# Altium schematic sheet sch6.SchDoc
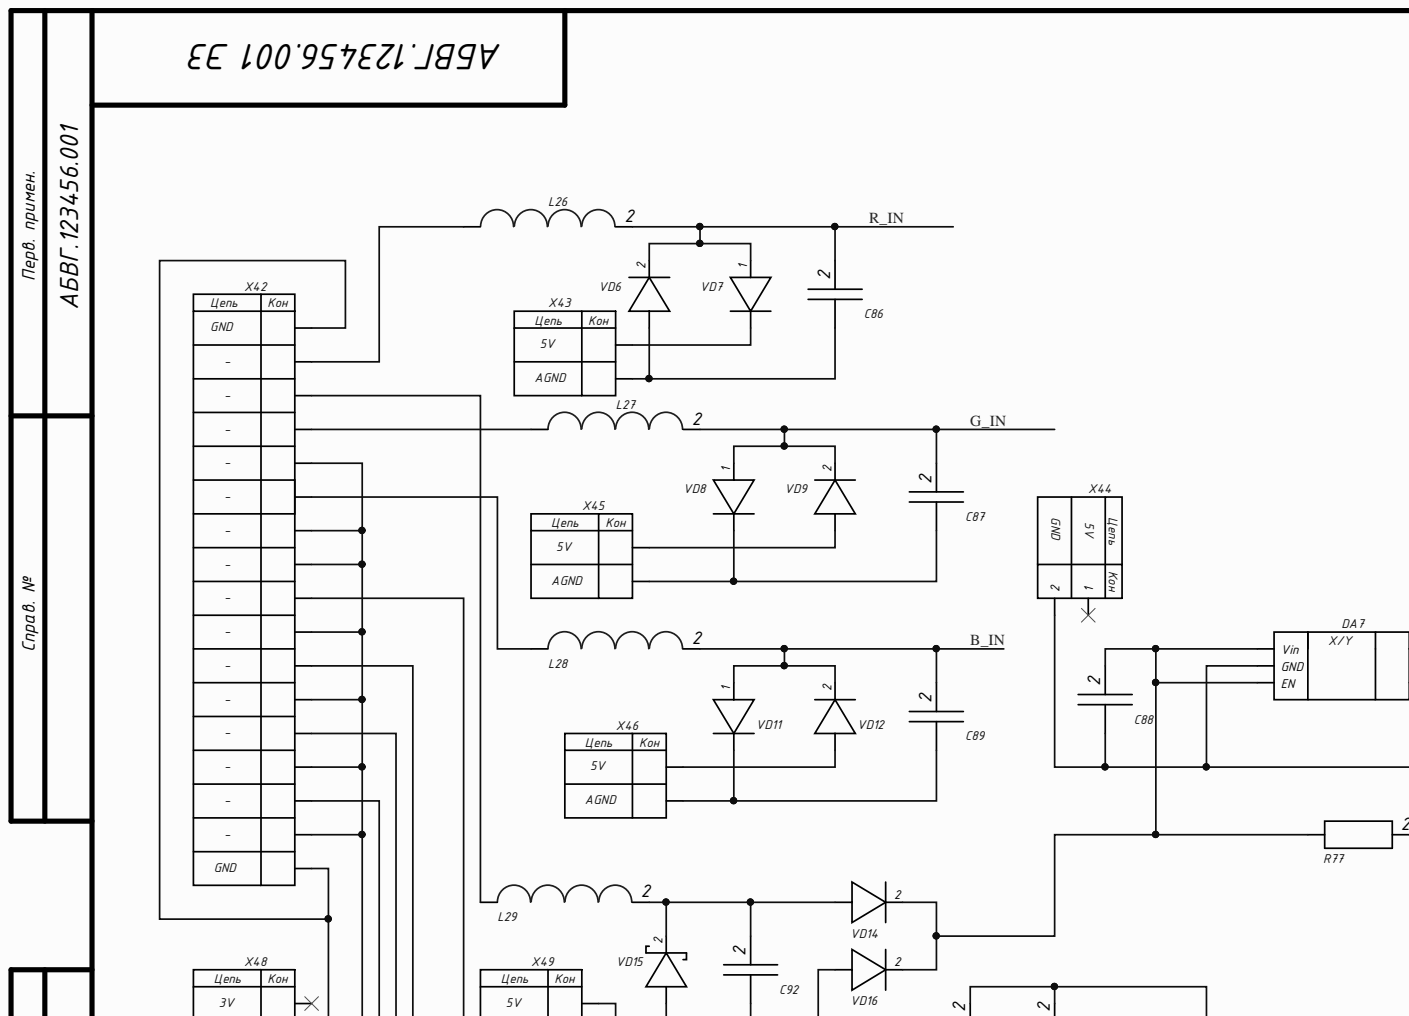
[diagram p0: sch6.SchDoc, top left]
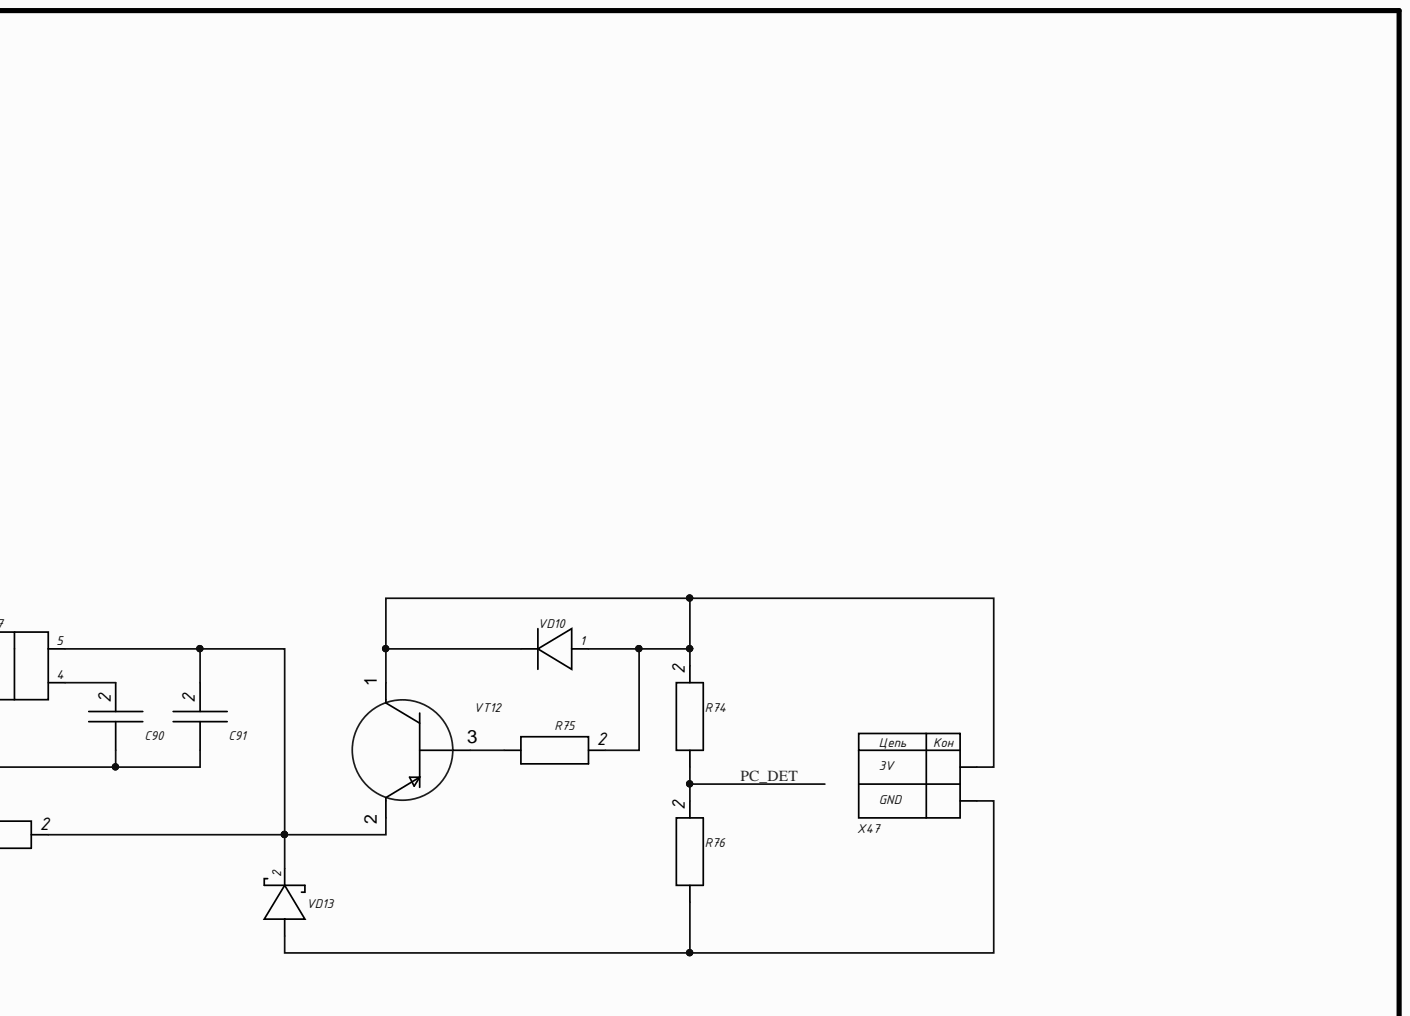
[diagram p1: sch6.SchDoc, top right]
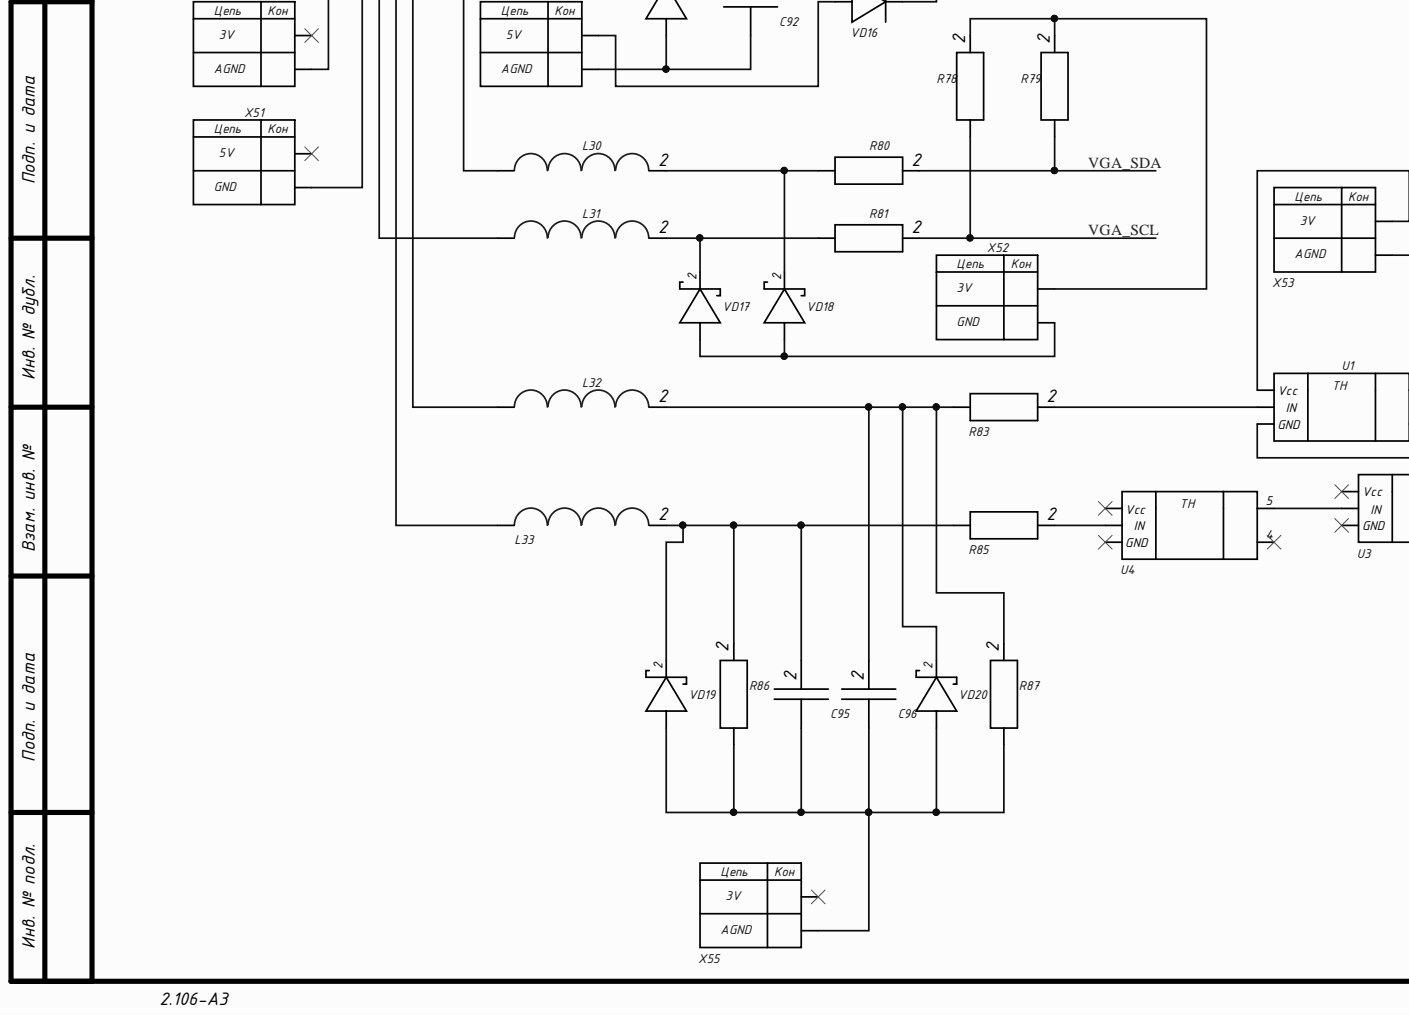
[diagram p2: sch6.SchDoc, bottom left]
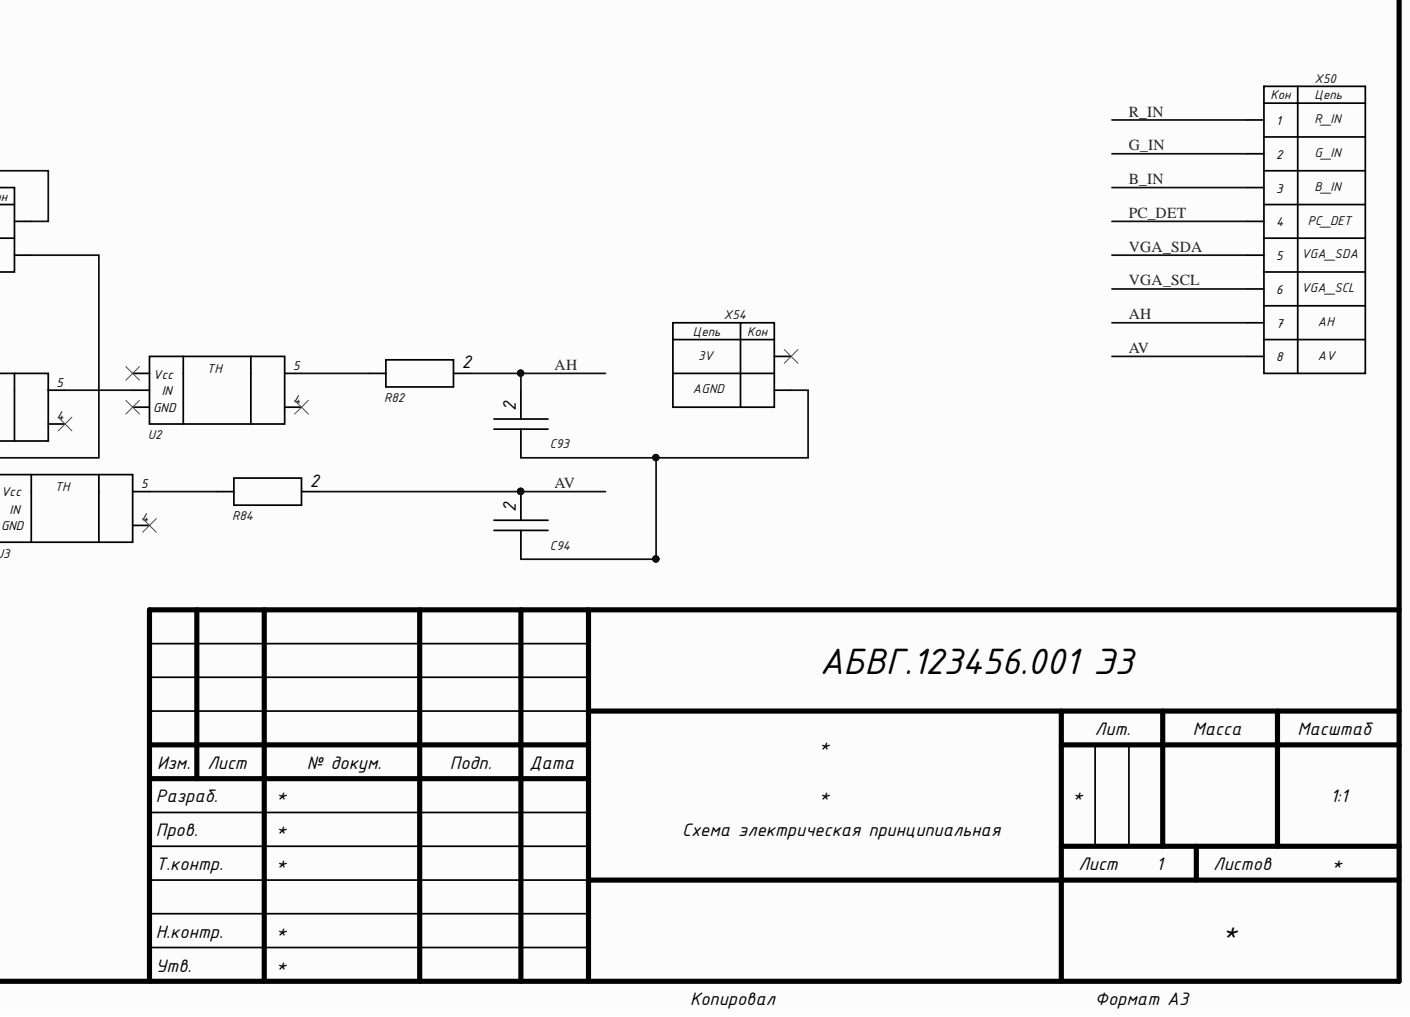
[diagram p3: sch6.SchDoc, bottom right]

## Parts
C86  (no value)  [Capacitor 0805]
C87  (no value)  [Capacitor 0805]
C88  (no value)  [Capacitor 0805]
C89  (no value)  [Capacitor 0805]
C90  (no value)  [Capacitor 0805]
C91  (no value)  [Capacitor 0805]
C92  (no value)  [Capacitor 0805]
C93  (no value)  [Capacitor 0805]
C94  (no value)  [Capacitor 0805]
C95  (no value)  [Capacitor 0805]
C96  (no value)  [Capacitor 0805]
DA7  (no value)  [MIC5205]
L26  (no value)  [Inductor 2520]
L27  (no value)  [Inductor 2520]
L28  (no value)  [Inductor 2520]
L29  (no value)  [Inductor 2520]
L30  (no value)  [Inductor 2520]
L31  (no value)  [Inductor 2520]
L32  (no value)  [Inductor 2520]
L33  (no value)  [Inductor 2520]
R74  (no value)  [Resistor 1206]
R75  (no value)  [Resistor 1206]
R76  (no value)  [Resistor 1206]
R77  (no value)  [Resistor 1206]
R78  (no value)  [Resistor 1206]
R79  (no value)  [Resistor 1206]
R80  (no value)  [Resistor 1206]
R81  (no value)  [Resistor 1206]
R82  (no value)  [Resistor 1206]
R83  (no value)  [Resistor 1206]
R84  (no value)  [Resistor 1206]
R85  (no value)  [Resistor 1206]
R86  (no value)  [Resistor 1206]
R87  (no value)  [Resistor 1206]
U1  (no value)  [MIC5205]
U2  (no value)  [MIC5205]
U3  (no value)  [MIC5205]
U4  (no value)  [MIC5205]
VD6  (no value)  [Diode]
VD7  (no value)  [Diode]
VD8  (no value)  [Diode]
VD9  (no value)  [Diode]
VD10  (no value)  [Diode]
VD11  (no value)  [Diode]
VD12  (no value)  [Diode]
VD13  (no value)  [Diode]
VD14  (no value)  [Diode]
VD15  (no value)  [Diode]
VD16  (no value)  [Diode]
VD17  (no value)  [Diode]
VD18  (no value)  [Diode]
VD19  (no value)  [Diode]
VD20  (no value)  [Diode]
VT12  (no value)  [Transistor 1]
X42  (no value)  [VGA Connector]
X43  (no value)  [VCC-GND]
X44  (no value)  [VCC-GND]
X45  (no value)  [VCC-GND]
X46  (no value)  [VCC-GND]
X47  (no value)  [VCC-GND]
X48  (no value)  [VCC-GND]
X49  (no value)  [VCC-GND]
X50  (no value)  [Outputs]
X51  (no value)  [VCC-GND]
X52  (no value)  [VCC-GND]
X53  (no value)  [VCC-GND]
X54  (no value)  [VCC-GND]
X55  (no value)  [VCC-GND]

## Nets
AH: C93.2 R82.2 X50.7
AV: C94.2 R84.2 X50.8
B_IN: C89.2 L28.2 VD11.1 VD12.2 X50.3
G_IN: C87.2 L27.2 VD8.1 VD9.2 X50.2
PC_DET: R74.1 R76.2 X50.4
R_IN: C86.2 L26.2 VD6.2 VD7.1 X50.1
VGA_SCL: R78.1 R81.2 X50.6
VGA_SDA: R79.1 R80.2 X50.5
NetC86_1: C86.1 VD6.1 X43.2
NetC87_1: C87.1 VD8.2 X45.2
NetC88_1: C88.1 C90.1 C91.1 DA7.2(GND) X44.2
NetC88_2: C88.2 DA7.1(Vin) DA7.3(EN) R77.1 VD14.2 VD16.2
NetC89_1: C89.1 VD11.2 X46.2
NetC90_2: C90.2 DA7.4
NetC91_2: C91.2 DA7.5 R77.2 VD13.2 VT12.2
NetC92_1: C92.1 VD15.1 X49.2
NetC92_2: C92.2 L29.2 VD14.1 VD15.2
NetC93_1: C93.1 C94.1 X54.2
NetC95_1: C95.1 C96.1 R86.1 R87.1 VD19.1 VD20.1 X55.2
NetC95_2: C95.2 L33.2 R85.1 R86.2 VD19.2
NetC96_2: C96.2 L32.2 R83.1 R87.2 VD20.2
NetL26_1: L26.1 X42.1
NetL27_1: L27.1 X42.2
NetL28_1: L28.1 X42.3
NetL29_1: L29.1 X42.9
NetL30_1: L30.1 X42.12
NetL30_2: L30.2 R80.1 VD18.2
NetL31_1: L31.1 X42.15
NetL31_2: L31.2 R81.1 VD17.2
NetL32_1: L32.1 X42.13
NetL33_1: L33.1 X42.14
NetR74_2: R74.2 R75.2 VD10.1 VD10.2 VT12.1 X47.1
NetR75_1: R75.1 VT12.3
NetR76_1: R76.1 VD13.1 X47.2
NetR78_2: R78.2 R79.2 X52.1
NetR82_1: R82.1 U2.5
NetR83_2: R83.2 U1.2(IN)
NetR84_1: R84.1 U3.5
NetR85_2: R85.2 U4.2(IN)
NetU1_1: U1.1(Vcc) X53.1
NetU1_3: U1.3(GND) X53.2
NetU1_5: U1.5 U2.2(IN)
NetU3_2: U3.2(IN) U4.5
NetVD7_2: VD7.2 X43.1
NetVD9_1: VD9.1 X45.1
NetVD12_1: VD12.1 X46.1
NetVD16_1: VD16.1 X49.1
NetVD17_1: VD17.1 VD18.1 X52.2
NetX42_4: X42.4 X42.5 X42.6 X42.7 X42.8 X42.10 X42.11 X51.2
NetX42_16: X42.16 X42.17 X48.2

Not connected: U1 4; U2 1(Vcc) 3(GND) 4; U3 1(Vcc) 3(GND) 4; U4 1(Vcc) 3(GND) 4; X44 1; X48 1; X51 1; X54 1; X55 1

## Library data of the parts
C86-C96 = Capacitor: Component Kind: Standard; Library Name: k7shared.IntLib
DA7 = MIC52: Component Kind: Standard; Library Name: k7sch6.IntLib
L26-L33 = Inductor: Component Kind: Standard; Library Name: k7shared.IntLib
R74-R87 = Resistor: Component Kind: Standard; Library Name: k7shared.IntLib
U1-U4 = Schmitt Inv: Component Kind: Standard; Library Name: k7sch6.IntLib
VD6-VD12 VD14 VD16 = Diode 1: Component Kind: Standard; Library Name: k7shared.IntLib
VD13 VD15 VD17-VD20 = Diode 2: Component Kind: Standard; Library Name: k7shared.IntLib
VT12 = Transistor 2: Component Kind: Standard; Library Name: k7shared.IntLib
X42 = VGA connector: Component Kind: Standard; Library Name: k7sch6.IntLib
X43 X45 X46 X49 = 5V-AGND: Component Kind: Standard; Library Name: k7shared.IntLib
X44 X51 = 5V-GND: Component Kind: Standard; Library Name: k7shared.IntLib
X47 X52 = VCC-GND R: Component Kind: Standard; Library Name: k7shared.IntLib
X48 X53-X55 = 3V-AGND: Component Kind: Standard; Library Name: k7shared.IntLib
X50 = Outputs: Component Kind: Standard; Library Name: k7sch6.IntLib
